# Altium schematic sheet 04_Sch.SchDoc (continued)

## Library data of the parts
AD4N AD4P TP8-TP11 TP13 = 101NJ-00001AU.01: SMD,材质磷青铜,塑高/针长无,耐压值50V,银白色,长x宽x高2.67x1.02x1.52mm; Allow Altenative Part: Y; Approved: YES; Categories: 连接器; COMPONENTLINK 1 DESCRIPTION: GetDatasheet; Database Table Name: Connector; Modify time: 2015年07月10号; Preferred: C; Remark: Verified by CRD（赖程奇） check list V1.0; serial number: 679; Category: 测试点; Component Kind: Standard; COMPONENTLINK 1 URL: http://www.keyelco.com/product-pdf.cfm?p=1353; Library Name: IA-04.DbLib; MOQ: 5000; Mounting Type: SurfaceMount; PACKAGE: Pipefittings; Part Number: 101NJ-00001AU.01; Pricing 1: 1.26; Supplier 1: KEYSTONE; Supplier Part Number 1: 5015; Value: 5015
C1 C3 C6 C8 C9 C21-C25 C30 C33 C35-C37 C39 C40 C43 C47 C50 C51 = 101CC-00142BW.01: SMD,电容量100nF,额定电压50V,偏差±10%,材质X7R,0603; Allow Altenative Part: Y; Approved: YES; Categories: 电容; COMPONENTLINK 1 DESCRIPTION: GetDatasheet; Database Table Name: CAP; Modify time: 2015年07月20; Rated Voltage: 50V; Remark: Verified by BU1（黄雄青） check list V1.0; serial number: 4; Temperature Coefficient: X7R; Tolerance: ±10%; Category: 贴片电容; Component Kind: Standard; COMPONENTLINK 1 URL: http://www.mouser.com/ds/2/447/UPY-GPHC_Y5V_6.3V-to-50V_5-14281.pdf; Library Name: IA-04.DbLib; MOQ: 1000; Mounting Type: SurfaceMount; PACKAGE: Tape&Reel; Part Number: 101CC-00142BW.01; Pricing 1: 0.029; Size: 603; Supplier 1: YAGEO; Supplier Part Number 1: CC0603KRX7R9BB104; Value: 100nF
C2 = 101CC-00418BW.01: SMD,电容量1.2nF,额定电压50V,偏差±10%,材质X7R,0603; Allow Altenative Part: Y; Approved: YES; Categories: 电容; COMPONENTLINK 1 DESCRIPTION: GetDatasheet; Database Table Name: CAP; Modify time: 2016年03月27号; Rated Voltage: 50V; Remark: Verified by BU1（黄雄青） check list V1.0; serial number: 113; Temperature Coefficient: X7R; Tolerance: ±10%; Category: 贴片电容; Component Kind: Standard; COMPONENTLINK 1 URL: http://www.yageo.com/documents/recent/UPY-GPHC_X7R_6.3V-to-50V_13.pdf; Library Name: IA-04.DbLib; MOQ: 4000; Mounting Type: SurfaceMount; PACKAGE: Tape&Reel; Part Number: 101CC-00418BW.01; Pricing 1: 0.02; Size: 0603; Supplier 1: YAGEO; Supplier Part Number 1: CC0603KRX7R9BB122; Value: 1.2nF
C4 = 101CC-00365BE.01: SMD,电容量10pF,额定电压50V,偏差±1%,材质NPO,0603; Allow Altenative Part: Y; Approved: YES; Categories: 电容; COMPONENTLINK 1 DESCRIPTION: GetDatasheet; Database Table Name: CAP; Modify time: 2015年07月10号; Rated Voltage: 50V; Remark: Verified by BU1（黄雄青） check list V1.0; serial number: 28; Temperature Coefficient: NPO; Tolerance: ±1%; Category: 贴片电容; Component Kind: Standard; COMPONENTLINK 1 URL: http://www.samsungsem.com/cn/product/passive-component/mlcc/general-n-high-cap/index.jsp; Library Name: IA-04.DbLib; MOQ: 4000; Mounting Type: SurfaceMount; PACKAGE: Tape&Reel; Part Number: 101CC-00365BE.01; Pricing 1: 0.14; Size: 0603; Supplier 1: Samsung; Supplier Part Number 1: CL10C100FB8NNNC; Value: 10pF
C5 = 101CC-00433BW.01: SMD,电容量100pF,额定电压50V,偏差±5%,材质NPO,0603; Allow Altenative Part: Y; Approved: YES; Categories: 电容; COMPONENTLINK 1 DESCRIPTION: GetDatasheet; Database Table Name: CAP; Modify time: 2016年03月27号; Preferred: C; Rated Voltage: 50V; Remark: Verified by BU1（黄雄青） check list V1.0; serial number: 146; Temperature Coefficient: NPO; Tolerance: ±5%; Category: 贴片电容; Component Kind: Standard; COMPONENTLINK 1 URL: http://www.yageo.com/documents/recent/UPY-GP_NP0_16V-to-50V_13.pdf; Library Name: IA-04.DbLib; MOQ: 4000; Mounting Type: SurfaceMount; PACKAGE: Tape&Reel; Part Number: 101CC-00433BW.01; Pricing 1: 0.02; Size: 603; Supplier 1: YAGEO; Supplier Part Number 1: CC0603JRNPO9BN101; Value: 100pF
C7 C34 C45 = 101CC-00250BW.01: SMD,电容量1uF,额定电压50V,偏差±10%,材质X7R,0603; Allow Altenative Part: Y; Approved: YES; Categories: 电容; COMPONENTLINK 1 DESCRIPTION: GetDatasheet; Database Table Name: CAP; Modify time: 2015年11月04号; Preferred: P; Rated Voltage: 50V; Remark: Verified by BU1（黄雄青） check list V1.0; serial number: 57; Temperature Coefficient: X7R; Tolerance: ±10%; Category: 贴片电容; Component Kind: Standard; COMPONENTLINK 1 URL: http://www.yageo.com/documents/recent/UPY-GPHC_X7R_6.3V-to-50V-v12.pdf; Library Name: IA-04.DbLib; MOQ: 4000; Mounting Type: SurfaceMount; PACKAGE: Tape&Reel; Part Number: 101CC-00250BW.01; Pricing 1: 0.752; Size: 0603; Supplier 1: YAGEO; Supplier Part Number 1: CC0603KRX7R9BB105; Value: 1uF
C10 C48 C49 = 101CE-00014GK.01: SMD,100uF,35V,±20%,6.3x5.8mm; Allow Altenative Part: Y; Approved: YES; Categories: 电容; COMPONENTLINK 1 DESCRIPTION: ShowDatasheet; Database Table Name: CAP_Alum; Modify time: 2016年08月22号; Remark: Verified by BU1（黄雄青） check list V1.0; serial number: 42; Applications: Automotive; Category: 电解电容; Component Kind: Standard; COMPONENTLINK 1 URL: http://industrial.panasonic.com/cdbs/www-data/pdf/RDE0000/ABA0000C35.pdf; Library Name: IA-04.DbLib; Max Thickness: 5.8; MOQ: 5000; Mounting Type: SurfaceMount; Operating Temperature: -55°C ~ 105°C; PACKAGE: Tape&Reel; Part Number: 101CE-00014GK.01; Pricing 1: 1.21; Rated Voltage: 35V; Size: 6.3; Supplier 1: Panasonic; Supplier Part Number 1: EEE-FT1V101AP; Tolerance: ±20%; Value: 100uF
C11-C15 C17-C20 = 101CC-00360OD.01: SMD,电容量10uF,额定电压50V,偏差±10%,材质X5R,1206; Allow Altenative Part: Y; Approved: YES; Categories: 电容; COMPONENTLINK 1 DESCRIPTION: GetDatasheet; Database Table Name: CAP; Modify time: 2017年01月24号; Rated Voltage: 50V; Remark: Verified by BU1（黄雄青） check list V1.0; serial number: 186; Temperature Coefficient: X5R; Tolerance: ±10%; Category: 贴片电容; Component Kind: Standard; COMPONENTLINK 1 URL: https://product.tdk.com/info/en/catalog/datasheets/mlcc_commercial_general_en.pdf; Library Name: IA-04.DbLib; MOQ: 2000; Mounting Type: SurfaceMount; PACKAGE: Tape&Reel; Part Number: 101CC-00360OD.01; Pricing 1: 2.2; Size: 1206; Supplier 1: TDK; Supplier Part Number 1: C3216X5R1H106K160AB; Value: 10uF
C16 = 101CE-00033GK.01: SMD,电容量100uF,额定电压50V,偏差±20%,8.0x10.2mm; Allow Altenative Part: Y; Categories: 电容; COMPONENTLINK 1 DESCRIPTION: ShowDatasheet; Database Table Name: CAP_Alum; Modify time: 2017年06月12号; Rated Voltage: 50V; serial number: 51; Tolerance: ±20%; Applications: Automotive; Category: 电解电容; Component Kind: Standard; COMPONENTLINK 1 URL: https://industrial.panasonic.com/cdbs/www-data/pdf/RDE0000/ABA0000C1145.pdf; Library Name: IA-04.DbLib; Max Thickness: 10.2; Mounting Type: SurfaceMount; Operating Temperature: -40°C ~ 85°C; PACKAGE: Tape&Reel; Part Number: 101CE-00033GK.01; Size: 8; Supplier 1: Panasonic; Supplier Part Number 1: EEE-1HA101UP; Value: 100uF
C26 C38 C41 C42 = 101CC-00442BW.01: SMD,电容量10uF,额定电压50V,偏差±10%,材质X7R,0805; Allow Altenative Part: Y; Approved: YES; Categories: 电容; COMPONENTLINK 1 DESCRIPTION: GetDatasheet; Database Table Name: CAP; Modify time: 2016年05月12号; Preferred: P; Rated Voltage: 50V; Remark: Verified by BU1（黄雄青） check list V1.0; serial number: 163; Temperature Coefficient: X7R; Tolerance: ±10%; Category: 贴片电容; Component Kind: Standard; COMPONENTLINK 1 URL: http://www.yageo.com/documents/recent/UPY-GPHC_X7R_6.3V-to-50V_13.pdf; Library Name: IA-04.DbLib; MOQ: 3000; Mounting Type: SurfaceMount; PACKAGE: Tape&Reel; Part Number: 101CC-00442BW.01; Pricing 1: 0.278; Size: 805; Supplier 1: YAGEO; Supplier Part Number 1: CC0805KKX7R9BB106; Value: 10uF
C27 C29 C32 = 101CC-00409BW.01: SMD,电容量22pF,额定电压50V,偏差±10%,材质NPO,0603; Allow Altenative Part: Y; Approved: YES; Categories: 电容; COMPONENTLINK 1 DESCRIPTION: GetDatasheet; Database Table Name: CAP; Modify time: 2017年01月24号; Preferred: C; Rated Voltage: 50V; Remark: Verified by BU1（黄雄青） check list V1.0; serial number: 236; Temperature Coefficient: NPO; Tolerance: ±10%; Category: 贴片电容; Component Kind: Standard; COMPONENTLINK 1 URL: http://www.yageo.com/NewPortal/yageodocoutput?fileName=/pdf/MLCC/UPY-GP_NP0_16V-to-50V_14.pdf; Library Name: IA-04.DbLib; MOQ: 4000; Mounting Type: SurfaceMount; PACKAGE: Tape&Reel; Part Number: 101CC-00409BW.01; Pricing 1: 0.05; Size: 603; Supplier 1: YAGEO; Supplier Part Number 1: CC0603KRNPO9BN220; Value: 22pF
C28 = 101CC-00425BW.01: SMD,电容量10nF,额定电压50V,偏差±10%,材质X7R,0603; Allow Altenative Part: Y; Approved: YES; Categories: 电容; COMPONENTLINK 1 DESCRIPTION: GetDatasheet; Database Table Name: CAP; Modify time: 2016年03月27号; Preferred: C; Rated Voltage: 50V; Remark: Verified by BU1（黄雄青） check list V1.0; serial number: 119; Temperature Coefficient: X7R; Tolerance: ±10%; Category: 贴片电容; Component Kind: Standard; COMPONENTLINK 1 URL: http://www.yageo.com/documents/recent/UPY-GPHC_X7R_6.3V-to-50V_13.pdf; Library Name: IA-04.DbLib; MOQ: 4000; Mounting Type: SurfaceMount; PACKAGE: Tape&Reel; Part Number: 101CC-00425BW.01; Pricing 1: 0.02; Size: 0603; Supplier 1: YAGEO; Supplier Part Number 1: CC0603KRX7R9BB103; Value: 10nF
C31 = 101CC-00507BW.01: SMD,电容量2.2nF,额定电压50V,偏差±5%,材质X7R,0603; Allow Altenative Part: Y; Categories: 电容; COMPONENTLINK 1 DESCRIPTION: GetDatasheet; Database Table Name: CAP; Modify time: 2017年03月10号; Rated Voltage: 50V; serial number: 252; Temperature Coefficient: X7R; Tolerance: ±5%; Category: 贴片电容; Component Kind: Standard; COMPONENTLINK 1 URL: http://pdf1.alldatasheet.com/datasheet-pdf/view/536436/YAGEO/CC0402KRX5R4BB/+_35WW8VUvG.PcGhAztzybgh+HPR+/datasheet.pdf; Library Name: IA-04.DbLib; Mounting Type: SurfaceMount; PACKAGE: Tape&Reel; Part Number: 101CC-00507BW.01; Size: 0603; Supplier 1: YAGEO; Supplier Part Number 1: CC0603JRX7R9BB222; Value: 2.2nF
C44 = 101CC-00150BW.01: SMD,电容量1nF,额定电压50V,偏差±10%,材质X7R,0603; Allow Altenative Part: Y; Approved: YES; Categories: 电容; COMPONENTLINK 1 DESCRIPTION: GetDatasheet; Database Table Name: CAP; Modify time: 2015年11月04号; Preferred: C; Rated Voltage: 50V; Remark: Verified by BU1（黄雄青） check list V1.0; serial number: 57; Temperature Coefficient: X7R; Tolerance: ±10%; Category: 贴片电容; Component Kind: Standard; COMPONENTLINK 1 URL: http://www.yageo.com/documents/recent/UPY-GPHC_X7R_6.3V-to-50V-v12.pdf; Library Name: IA-04.DbLib; MOQ: 4000; Mounting Type: SurfaceMount; PACKAGE: Tape&Reel; Part Number: 101CC-00150BW.01; Pricing 1: 0.0278; Size: 603; Supplier 1: YAGEO; Supplier Part Number 1: CC0603KRX7R9BB102; Value: 1nF
C46 = 101CC-00433BW.01: SMD,电容量100pF,额定电压50V,偏差±5%,材质NPO,0603; Allow Altenative Part: Y; Approved: YES; Categories: 电容; COMPONENTLINK 1 DESCRIPTION: GetDatasheet; Database Table Name: CAP; Modify time: 2016年03月27号; Preferred: C; Rated Voltage: 50V; Remark: Verified by BU1（黄雄青） check list V1.0; serial number: 123; Temperature Coefficient: NPO; Tolerance: ±5%; Category: 贴片电容; Component Kind: Standard; COMPONENTLINK 1 URL: http://www.yageo.com/documents/recent/UPY-GP_NP0_16V-to-50V_13.pdf; Library Name: IA-04.DbLib; MOQ: 4000; Mounting Type: SurfaceMount; PACKAGE: Tape&Reel; Part Number: 101CC-00433BW.01; Pricing 1: 0.02; Size: 0603; Supplier 1: YAGEO; Supplier Part Number 1: CC0603JRNPO9BN101; Value: 100pF
Cff1 = 101CC-00142BW.01: SMD,电容量100nF,额定电压50V,偏差±10%,材质X7R,0603; Allow Altenative Part: Y; Part Number: NC--101CC-00142BW.01; Approved: YES; Categories: 电容; COMPONENTLINK 1 DESCRIPTION: GetDatasheet; Database Table Name: CAP; Modify time: 2015年07月20; Rated Voltage: 50V; Remark: Verified by BU1（黄雄青） check list V1.0; serial number: 4; Temperature Coefficient: X7R; Tolerance: ±10%; Category: 贴片电容; Component Kind: Standard; COMPONENTLINK 1 URL: http://www.mouser.com/ds/2/447/UPY-GPHC_Y5V_6.3V-to-50V_5-14281.pdf; Library Name: IA-04.DbLib; MOQ: 1000; Mounting Type: SurfaceMount; PACKAGE: Tape&Reel; Pricing 1: 0.029; Size: 603; Supplier 1: YAGEO; Supplier Part Number 1: CC0603KRX7R9BB104; Value: 100nF
CH_N CH_P DA_O GND_MCU J5-J13 PP3V3_MCU PP5V = 1R5: SMD,直径1.5mm; Allow Altenative Part: Y; Categories: 连接器; Database Table Name: OTS; serial number: 411; Category: 测试点; Component Kind: Standard; Library Name: IA-04.DbLib; Mounting Type: SurfaceMount; PACKAGE: Pipefittings; Part Number: 1R5
D1 = (no symbol name): SMD,封装外壳SMC（DO-214AB）,额定电压40V,电流5A; Allow Altenative Part: Y; Approved: YES; Categories: 二极管; COMPONENTLINK 1 DESCRIPTION: GetDatasheet; Modify time: 2018年06月25号; Rated Current: 20A; Rated Voltage: 100V; Remark: Verified by BU2（孙泽） check list V1.0; serial number: 127; Category: 肖特基二极管; Component Kind: Standard; COMPONENTLINK 1 URL: https://www.st.com/resource/en/datasheet/stps20m100s.pdf; MOQ: 3000; Mounting Type: SurfaceMount; PACKAGE: Tape&Reel; Pricing 1: 8.4; Supplier 1: ST; Supplier Part Number 1: STPS20M100SG-TR; Value: STPS20M100SG-TR; Library Name: IAA1847400_Tiger-KB-FATP For Desk-N PS Board .SCHLIB
D2 = 101DS-00016BA.01: SMD,封装外壳SOD-323（0805）,额定电压20V,电流1A; Allow Altenative Part: Y; Approved: YES; Categories: 二极管; COMPONENTLINK 1 DESCRIPTION: GetDatasheet; Database Table Name: Diode; Modify time: 2015年07月28号; Rated Current: 1A; Rated Voltage: 20V; Remark: Verified by CRD（张宗良） check list V1.0; serial number: 35; Category: 肖特基二极管; Component Kind: Standard; COMPONENTLINK 1 URL: http://www.onsemi.com/pub_link/Collateral/NSR0320MW2T1-D.PDF; Library Name: IA-04.DbLib; MOQ: 1000; Mounting Type: SurfaceMount; PACKAGE: Tape&Reel; Part Number: 101DS-00016BA.01; Pricing 1: 0.61; Supplier 1: ON; Supplier Part Number 1: NSR0320MW2T1G; Value: NSR0320MW2T1G
D3 = 101DG-00024DC.01: SMD,SOD-323（0805）,额定电压75V,电流150mA; Allow Altenative Part: Y; Approved: YES; Categories: 二极管; COMPONENTLINK 1 DESCRIPTION: OpenDatasheet; Database Table Name: Diode; Modify time: 2017年01月07号; Rated Current: 150mA; Rated Voltage: 75V; Remark: Verified by CRD（张宗良） check list V1.0; serial number: 144; Category: 普通二极管; Component Kind: Standard; Library Name: IA-04.DbLib; Mounting Type: SurfaceMount; PACKAGE: Tape&Reel; Part Number: 101DG-00024DC.01; Supplier 1: 长电科技; Supplier Part Number 1: 1N4148WS; Value: 1N4148WS
D4 D5 = 101DT-00033AF.01: SMD,SMC（DO-214AB）,额定电压40V,电流5A; Allow Altenative Part: Y; Approved: YES; Categories: 二极管; COMPONENTLINK 1 DESCRIPTION: GetDatasheet; Database Table Name: Diode; Modify time: 2015年11月11号; Rated Current: 5A; Rated Voltage: 40V; Remark: Verified by CRD（张宗良） check list V1.0; serial number: 22; Category: 肖特基二极管; Component Kind: Standard; COMPONENTLINK 1 URL: http://www.diodes.com/_files/datasheets/ds13012.pdf; Library Name: IA-04.DbLib; MOQ: 3000; Mounting Type: SurfaceMount; PACKAGE: Tape&Reel; Part Number: 101DT-00033AF.01; Pricing 1: 1.31285; Supplier 1: DIODES; Supplier Part Number 1: B540C-13-F; Value: B540C-13-F
F1 = 101FP-00031BI.01: SMD,0805,额定电压6V,额定电流0.5A,快断; Allow Altenative Part: Y; Approved: YES; Categories: 保险丝; COMPONENTLINK 1 DESCRIPTION: GetDatasheet; Database Table Name: Fuse; Modify time: 2017年01月07号; Rated Current: .5A; Remark: Verified by BU1（黄雄青） check list V1.0; serial number: 38; Category: 自恢复保险丝; Component Kind: Standard; Library Name: IA-04.DbLib; Mounting Type: SurfaceMount; PACKAGE: Tape&Reel; Part Number: 101FP-00031BI.01; Supplier 1: SEA&LAND; Supplier Part Number 1: SMD0805-050-6V; Value: SMD0805-050-6V
J1 = 101NH-00001DJ.01: SMD,座子,封装脚距1.27mm,180度,2x20PIN,塑高/针长5.72mm/无,黑色; Allow Altenative Part: Y; Approved: YES; Categories: 连接器; COMPONENTLINK 1 DESCRIPTION: GetDatasheet; Database Table Name: Connector; Modify time: 2016年1月11号; Preferred: P; Remark: Verified by CRD（赖程奇） check list V1.0; serial number: 313; Category: 板对板连接器; Component Kind: Standard; COMPONENTLINK 1 URL: http://www.hkjb888.com/product.asp?page=9; Library Name: IA-04.DbLib; MOQ: 100; Mounting Type: SurfaceMount; PACKAGE: Pipefittings; Part Number: 101NH-00001DJ.01; Supplier 1: 骏佳; Supplier Part Number 1: BCM2571-4001B01B1BB; Value: BCM2571-4001B01B1BB
J2 = 101NH-00041BG.01: SMD,座子,封装脚距1.27mm,180度,2x12PIN,塑高/针长5.72mm/无,黑色; Allow Altenative Part: Y; Approved: YES; Categories: 连接器; COMPONENTLINK 1 DESCRIPTION: GetDatasheet; Database Table Name: Connector; Modify time: 2015年07月24号; Preferred: P; Remark: Verified by CRD（赖程奇） check list V1.0; serial number: 305; Category: 板对板连接器; Component Kind: Standard; COMPONENTLINK 1 URL: https://www.samtec.com/ftppub/pdf/tfm.pdf; Library Name: IA-04.DbLib; MOQ: 200; Mounting Type: SurfaceMount; PACKAGE: Pipefittings; Part Number: 101NH-00041BG.01; Supplier 1: 莱沃; Supplier Part Number 1: 2100113-12*2; Value: 2100113-12*2
J3 = 102NM-00004BV.01: DIP,封装脚距2.54mm,180度,1x6PIN,黑色; Allow Altenative Part: Y; Approved: YES; Categories: 连接器; COMPONENTLINK 1 DESCRIPTION: GetDatasheet; Database Table Name: Connector; Modify time: 2015年07月10号; Remark: Verified by CRD（赖程奇） check list V1.0; serial number: 103; Category: 单排母; Component Kind: Standard; COMPONENTLINK 1 URL: http://katalog.we-online.de/en/em/613_0xx_118_21/613_014_118_21?m=n&sp=http%3A%2F%2Fwww.we-online.com%2Fweb%2Fen%2Fwuerth_elektronik%2Fsearchpage.php%3Fsearch%3D61301411821; Library Name: IA-04.DbLib; MOQ: 100; Mounting Type: ThroughHole; PACKAGE: Bulk; Part Number: 102NM-00004BV.01; Pricing 1: 1.67; Supplier 1: wurth; Supplier Part Number 1: 61300611821; Value: 61300611821
J4 = 102NL-00006DJ.01: DIP,封装脚距2.54mm,180度,1x4PIN,上针/下针6.0/3.0mm,黑色; Allow Altenative Part: Y; Approved: YES; Categories: 连接器; COMPONENTLINK 1 DESCRIPTION: GetDatasheet; Database Table Name: Connector; Modify time: 2016年08月22号; Remark: Verified by CRD（赖程奇） check list V1.0; serial number: 216; Category: 单排针; Component Kind: Standard; COMPONENTLINK 1 URL: http://www.hkjb888.com/product.asp?action=detailed&id=1099&page=3&pid=245; Library Name: IA-04.DbLib; MOQ: 500; Mounting Type: ThroughHole; PACKAGE: Bulk; Part Number: 102NL-00006DJ.01; Pricing 1: 0.03; Supplier 1: 骏佳; Supplier Part Number 1: PAS1251-0401A01B1BA; Value: PAS1251-0401A01B1BA
J14 = 102NB-00022CY.01: DIP,座子,封装脚距2.54mm,180度,1x2PIN,塑高/针长7.0/3.40mm,白色; Allow Altenative Part: Y; Approved: YES; Categories: 连接器; COMPONENTLINK 1 DESCRIPTION: GetDatasheet; Database Table Name: Connector; Modify time: 2016年1月11号; Preferred: C; Remark: Verified by CRD（赖程奇） check list V1.0; serial number: 45; Category: XH连接器; Component Kind: Standard; COMPONENTLINK 1 URL: http://www.fyconn.com/uploadfile/jpg/324.jpg; Library Name: IA-04.DbLib; MOQ: 100; Mounting Type: ThroughHole; PACKAGE: Bulk; Part Number: 102NB-00022CY.01; Pricing 1: 0.251; Supplier 1: 富盈源; Supplier Part Number 1: XH-02A; Value: XH-02A
L1 = 101LP-00036BS.01: SMD,电感值33uH,精度±20%,额定电流10.7A,屏蔽式,17.15x17.15/7mm; Allow Altenative Part: Y; Approved: YES; Categories: 电感; COMPONENTLINK 1 DESCRIPTION: OpenDatasheet; Database Table Name: Inductor; Modify time: 2015年11月21号; Rated Current: 10.7A; Remark: Verified by BU1（黄雄青） check list V1.0; serial number: 24; Tolerance: ±20%; Category: 功率电感; Component Kind: Standard; COMPONENTLINK 1 URL: http://www.vishay.com/docs/34282/lp67gz11.pdf; Library Name: IA-04.DbLib; MOQ: 1000; Mounting Type: SurfaceMount; Operating Temperature: -55°C~155°C; PACKAGE: Tape&Reel; Part Number: 101LP-00036BS.01; Pricing 1: 19.635; Size: 17.15x17.15x7mm; Supplier 1: Vishay; Supplier Part Number 1: IHLP6767GZER330M11; Value: 33uH
L2 = 101LF-00015AV.01: SMD,电感值无,额定电流1.5A,屏蔽式,0805,阻值/频率600R/100MHz; Allow Altenative Part: Y; Approved: YES; Categories: 电感; COMPONENTLINK 1 DESCRIPTION: OpenDatasheet; Database Table Name: Inductor; Modify time: 2016年05月12号; Rated Current: 1.5A; Remark: Verified by BU1（黄雄青） check list V1.0; serial number: 51; Category: 磁珠; Component Kind: Standard; COMPONENTLINK 1 URL: http://search.murata.co.jp/Ceramy/image/img/PDF/ENG/L0110S0100BLM21P.pdf; Library Name: IA-04.DbLib; MOQ: 4000; Mounting Type: SurfaceMount; Operating Temperature: -55°C ~ 125°C; PACKAGE: Tape&Reel; Part Number: 101LF-00015AV.01; Pricing 1: 0.219; Size: 805; Supplier 1: Murata; Supplier Part Number 1: BLM21PG601SN1D; Value: 600R/100MHz
L3 L4 = 101LF-00024OD.01: SMD,电感值无,额定电流1A,屏蔽式,0603,阻值/频率470R/100MHz; Allow Altenative Part: Y; Approved: YES; Categories: 电感; COMPONENTLINK 1 DESCRIPTION: OpenDatasheet; Database Table Name: Inductor; Modify time: 2015年08月04号; Preferred: P; Rated Current: 1A; Remark: Verified by BU1（黄雄青） check list V1.0; serial number: 6; Tolerance: ±25%; Category: 磁珠; Component Kind: Standard; COMPONENTLINK 1 URL: http://product.tdk.com; Library Name: IA-04.DbLib; MOQ: 4000; Mounting Type: SurfaceMount; PACKAGE: Tape&Reel; Part Number: 101LF-00024OD.01; Pricing 1: 0.06; Size: 603; Supplier 1: TDK; Supplier Part Number 1: MPZ1608B471ATA00; Value: 470R/100MHz
L_MCU1 LED1 LED2 = 101DL-00049CH: SMD,0603,2V,普亮,黄色,; Allow Altenative Part: Y; Categories: 二极管; COMPONENTLINK 1 DESCRIPTION: GetDatasheet; Database Table Name: Diode; Modify time: 2015年11月11号; Remark: 旧料号23011562; serial number: 228; Category: 发光二极管; Component Kind: Standard; COMPONENTLINK1DESCRIPTION: Get Datasheet; COMPONENTLINK 1 URL: http://media.digikey.com/pdf/Data%20Sheets/Everlight%20PDFs/19-213UYC,S530-A3,TR8.pdf; COMPONENTLINK1URL: http://media.digikey.com/pdf/Data%20Sheets/Everlight%20PDFs/19-213UYC,S530-A3,TR8.pdf; Footprint Path: 06-Diode\Diode.PcbLib; Footprint Ref: LED-0603; Library Name: IA-04.DbLib; Library Path: 06-Diode\Diode.SchLib; Library Ref: 19-213UYC/S530-A3/TR8; MOQ: 9000; Mounting Type: SurfaceMount; PACKAGE: Tape&Reel; Part Number: 101DL-00049CH; Pricing 1: .385; Rated Voltage: 2V; Supplier 1: 台湾亿光; Supplier Part Number 1: 19-213UYC/S530-A3/TR8; Value: 19-213UYC/S530-A3/TR8
R1 = 101RC-01669BW.01: SMD,阻值10R,精度±1%,0603,额定功率1/10W; Allow Altenative Part: Y; Approved: YES; Categories: 电阻; COMPONENTLINK 1 DESCRIPTION: OpenDatasheet; Database Table Name: RES; Modify time: 2016年03月27号; Preferred: C; Rated Power: 1/10W; Remark: Verified by BU2（叶俊雄） check list V1.0; serial number: 494; Tolerance: ±1%; Category: 贴片电阻; Component Kind: Standard; COMPONENTLINK 1 URL: http://www.yageo.com/NewPortal/yageodocoutput?fileName=/pdf/R-Chip/PYu-RC_Group_51_RoHS_L_71.pdf; Library Name: IA-04.DbLib; MOQ: 5000; Mounting Type: SurfaceMount; PACKAGE: Tape&Reel; Part Number: 101RC-01669BW.01; Pricing 1: 0.01; Size: 0603; Supplier 1: Yageo; Supplier Part Number 1: RC0603FR-0710RL; Value: 10R
R2-R9 R18 R21 R22 R33-R35 R37 R42-R45 R52 = 101RC-02008BW.01: SMD,阻值0R,精度±1%,0402,额定功率1/16W; Allow Altenative Part: Y; Approved: YES; Categories: 电阻; COMPONENTLINK 1 DESCRIPTION: OpenDatasheet; Database Table Name: RES; Modify time: 2017年01月07号; Preferred: C; Rated Power: 1/16W; Remark: Verified by BU3（吴明徽）check list V1.0; serial number: 647; Tolerance: ±1%; Category: 贴片电阻; Component Kind: Standard; Library Name: IA-04.DbLib; Mounting Type: SurfaceMount; PACKAGE: Tape&Reel; Part Number: 101RC-02008BW.01; Size: 0402; Supplier 1: YAGEO; Supplier Part Number 1: RC0402FR-070RL; Value: 0R
R10 = 101RC-02279BW.01: 安装方式SMD,阻值49.9k,精度±0.1%,0603,额定功率1/10W; Allow Altenative Part: Y; Categories: 电阻; COMPONENTLINK 1 DESCRIPTION: OpenDatasheet; Database Table Name: RES; Modify time: 2018年5月12号; Rated Power: 1/10W; serial number: 860; Tolerance: ±0.1%; Category: 贴片电阻; Component Kind: Standard; COMPONENTLINK 1 URL: http://www.mouser.cn/datasheet/2/447/PYu-RC_Group_51_RoHS_L_9-1314892.pdf; Library Name: IA-04.DbLib; Mounting Type: SurfaceMount; PACKAGE: Tape&Reel; Part Number: 101RC-02279BW.01; Size: 0603; Supplier 1: YAGEO; Supplier Part Number 1: RT0603BRE0749K9L; Value: 49.9k
R11 = 101RC-01171BS.01: SMD,阻值50K,精度±0.1%,0603,额定功率3/20W; Allow Altenative Part: Y; Approved: YES; Categories: 电阻; COMPONENTLINK 1 DESCRIPTION: OpenDatasheet; Database Table Name: RES; Modify time: 2015年11月27号; Rated Power: 3/20W; Remark: Verified by BU3（吴明徽）check list V1.0; serial number: 163; Tolerance: ±0.1%; Category: 贴片电阻; Component Kind: Standard; COMPONENTLINK 1 URL: http://www.vishay.com/docs/60057/pnm.pdf; Library Name: IA-04.DbLib; MOQ: 5000; Mounting Type: SurfaceMount; PACKAGE: Tape&Reel; Part Number: 101RC-01171BS.01; Pricing 1: 9.21691; Size: 0603; Supplier 1: Vishay; Supplier Part Number 1: PNM0603E5002BST5; Value: 50K
R12 R13 R36 = 101RC-00400BW.01: SMD,阻值1K,精度±1%,0603,额定功率1/10W; Allow Altenative Part: Y; Approved: YES; Categories: 电阻; COMPONENTLINK 1 DESCRIPTION: OpenDatasheet; Database Table Name: RES; Modify time: 2015年11月27号; Preferred: P; Rated Power: 1/10W; Remark: Verified by BU2（叶俊雄）& BU4（陈雪莹） check list V1.0; serial number: 79; Tolerance: ±1%; Category: 贴片电阻; Component Kind: Standard; COMPONENTLINK 1 URL: http://www.yageo.com/exep/pages/download/literatures/PYu-R_Marking_2.pdf; Library Name: IA-04.DbLib; MOQ: 5000; Mounting Type: SurfaceMount; PACKAGE: Tape&Reel; Part Number: 101RC-00400BW.01; Pricing 1: 0.01287; Size: 0603; Supplier 1: YAGEO; Supplier Part Number 1: RC0603FR-071KL; Value: 1K
R14 = 101RC-01935BW.01: SMD,阻值200R,精度±0.1%,0603,额定功率1/10W; Allow Altenative Part: Y; Approved: YES; Categories: 电阻; COMPONENTLINK 1 DESCRIPTION: OpenDatasheet; Database Table Name: RES; Modify time: 2016年10月28号; Rated Power: 1/10W; Remark: Verified by BU3（吴明徽）check list V1.0; serial number: 563; Tolerance: ±0.1%; Category: 贴片电阻; Component Kind: Standard; COMPONENTLINK 1 URL: http://www.yageo.com/documents/recent/PYu-RT_1-to-0.05_RoHS_L_6.pdf; Library Name: IA-04.DbLib; MOQ: 5000; Mounting Type: SurfaceMount; PACKAGE: Tape&Reel; Part Number: 101RC-01935BW.01; Pricing 1: 0.42; Size: 0603; Supplier 1: YAGEO; Supplier Part Number 1: RT0603BRE07200RL; Value: 200R
R15 R26-R28 = 101RC-01741BW.01: SMD,阻值10K,精度±1%,0603,额定功率1/10W; Allow Altenative Part: Y; Approved: YES; Categories: 电阻; COMPONENTLINK 1 DESCRIPTION: OpenDatasheet; Database Table Name: RES; Modify time: 2016年03月27号; Preferred: P; Rated Power: 1/10W; Remark: Verified by BU2（叶俊雄）& BU4（陈雪莹） check list V1.0; serial number: 491; Tolerance: ±1%; Category: 贴片电阻; Component Kind: Standard; COMPONENTLINK 1 URL: http://www.yageo.com/NewPortal/yageodocoutput?fileName=/pdf/R-Chip/PYu-RC_Group_51_RoHS_L_8.pdf; Library Name: IA-04.DbLib; MOQ: 5000; Mounting Type: SurfaceMount; PACKAGE: Tape&Reel; Part Number: 101RC-01741BW.01; Pricing 1: 0.01; Size: 0603; Supplier 1: Yageo; Supplier Part Number 1: RC0603FR-0710KL; Value: 10K
R16 = 101RC-01741BW.01: SMD,阻值10K,精度±1%,0603,额定功率1/10W; Allow Altenative Part: Y; Approved: YES; Categories: 电阻; COMPONENTLINK 1 DESCRIPTION: OpenDatasheet; Database Table Name: RES; Modify time: 2016年03月27号; Preferred: P; Rated Power: 1/10W; Remark: Verified by BU2（叶俊雄）& BU4（陈雪莹） check list V1.0; serial number: 465; Tolerance: ±1%; Category: 贴片电阻; Component Kind: Standard; COMPONENTLINK 1 URL: http://www.yageo.com/NewPortal/yageodocoutput?fileName=/pdf/R-Chip/PYu-RC_Group_51_RoHS_L_8.pdf; Library Name: IA-04.DbLib; MOQ: 5000; Mounting Type: SurfaceMount; PACKAGE: Tape&Reel; Part Number: 101RC-01741BW.01; Pricing 1: 0.01; Size: 0603; Supplier 1: Yageo; Supplier Part Number 1: RC0603FR-0710KL; Value: 10K
R17 = 101RC-00062-0.01: SMD,阻值330K,精度±1%,0603,额定功率1/10W; Allow Altenative Part: Y; Approved: YES; Categories: 电阻; COMPONENTLINK 1 DESCRIPTION: OpenDatasheet; Database Table Name: RES; Modify time: 2015年07月10号; Rated Power: 1/10W; Remark: Verified by BU3（吴明徽）check list V1.0; serial number: 125; Tolerance: ±1%; Category: 贴片电阻; Component Kind: Standard; Library Name: IA-04.DbLib; MOQ: 5000; Mounting Type: SurfaceMount; PACKAGE: Tape&Reel; Part Number: 101RC-00062-0.01; Size: 0603; Value: 330K
R19 = 101RC-00096-0.01: SMD,阻值57.6K,精度±1%,0603,额定功率1/10W; Allow Altenative Part: Y; Approved: YES; Categories: 电阻; COMPONENTLINK 1 DESCRIPTION: OpenDatasheet; Database Table Name: RES; Modify time: 2015年07月10号; Rated Power: 1/10W; Remark: Verified by BU3（吴明徽）check list V1.0; serial number: 166; Tolerance: ±1%; Category: 贴片电阻; Component Kind: Standard; Library Name: IA-04.DbLib; MOQ: 5000; Mounting Type: SurfaceMount; PACKAGE: Tape&Reel; Part Number: 101RC-00096-0.01; Size: 0603; Value: 57.6K
R20 = 101RC-01938BW.01: SMD,阻值2K,精度±0.1%,0603,额定功率1/10W; Allow Altenative Part: Y; Approved: YES; Categories: 电阻; COMPONENTLINK 1 DESCRIPTION: OpenDatasheet; Database Table Name: RES; Modify time: 2016年10月28号; Rated Power: 1/10W; Remark: Verified by BU3（吴明徽）check list V1.0; serial number: 567; Tolerance: ±0.1%; Category: 贴片电阻; Component Kind: Standard; COMPONENTLINK 1 URL: http://www.yageo.com/documents/recent/PYu-RT_1-to-0.05_RoHS_L_6.pdf; Library Name: IA-04.DbLib; MOQ: 5000; Mounting Type: SurfaceMount; PACKAGE: Tape&Reel; Part Number: 101RC-01938BW.01; Pricing 1: 0.42; Size: 0603; Supplier 1: YAGEO; Supplier Part Number 1: RT0603BRE072KL; Value: 2K
R23 = 101RC-01697BW.01: SMD,阻值10K,精度±0.1%,0603,额定功率1/10W; Allow Altenative Part: Y; Approved: YES; Categories: 电阻; COMPONENTLINK 1 DESCRIPTION: OpenDatasheet; Database Table Name: RES; Modify time: 2016年2月17号; Preferred: C; Rated Power: 1/10W; Remark: Verified by BU2（叶俊雄）& BU3（杨培）& CRD（赖程奇）check list V1.0; serial number: 438; Tolerance: ±0.1%; Category: 贴片电阻; Component Kind: Standard; COMPONENTLINK 1 URL: http://www.yageo.com/documents/recent/PYu-RT_1-to-0.05_RoHS_L_6.pdf; Library Name: IA-04.DbLib; MOQ: 5000; Mounting Type: SurfaceMount; PACKAGE: Tape&Reel; Part Number: 101RC-01697BW.01; Pricing 1: 0.43559; Size: 0603; Supplier 1: YAGEO; Supplier Part Number 1: RT0603BRD0710KL; Value: 10K
R24 R29 R31 R38 = 101RC-01748BW.01: SMD,阻值0R,精度±1%,0603,额定功率1/10W; Allow Altenative Part: Y; Approved: YES; Categories: 电阻; COMPONENTLINK 1 DESCRIPTION: OpenDatasheet; Database Table Name: RES; Modify time: 2016年03月27号; Preferred: P; Rated Power: 1/10W; Remark: Verified by BU2（叶俊雄）& BU4（陈雪莹）check list V1.0; serial number: 496; Tolerance: ±1%; Category: 贴片电阻; Component Kind: Standard; COMPONENTLINK 1 URL: http://www.yageo.com/NewPortal/yageodocoutput?fileName=/pdf/R-Chip/PYu-RC_Group_51_RoHS_L_74.pdf; Library Name: IA-04.DbLib; MOQ: 5000; Mounting Type: SurfaceMount; PACKAGE: Tape&Reel; Part Number: 101RC-01748BW.01; Pricing 1: 0.01; Size: 0603; Supplier 1: Yageo; Supplier Part Number 1: RC0603FR-070RL; Value: 0R
R30 = 101RC-00557-0.01: SMD,阻值34.8K,精度±1%,0603,额定功率1/10W; Allow Altenative Part: Y; Approved: YES; Categories: 电阻; COMPONENTLINK 1 DESCRIPTION: OpenDatasheet; Database Table Name: RES; Modify time: 2015年07月10号; Rated Power: 1/10W; Remark: Verified by BU3（吴明徽）check list V1.0; serial number: 129; Tolerance: ±1%; Category: 贴片电阻; Component Kind: Standard; Library Name: IA-04.DbLib; MOQ: 5000; Mounting Type: SurfaceMount; PACKAGE: Tape&Reel; Part Number: 101RC-00557-0.01; Size: 0603; Value: 34.8K
R39 = 101RC-01224BW.01: SMD,阻值100R,精度±0.1%,0603,额定功率1/10W; Allow Altenative Part: Y; Approved: YES; Categories: 电阻; COMPONENTLINK 1 DESCRIPTION: OpenDatasheet; Database Table Name: RES; Modify time: 2016年1月11号; Rated Power: 1/10W; Remark: Verified by BU2（叶俊雄） check list V1.0; serial number: 419; Tolerance: ±0.1%; Category: 贴片电阻; Component Kind: Standard; COMPONENTLINK 1 URL: http://www.yageo.com/documents/recent/PYu-RT_1-to-0.05_RoHS_L_6.pdf; Library Name: IA-04.DbLib; MOQ: 5000; Mounting Type: SurfaceMount; PACKAGE: Tape&Reel; Part Number: 101RC-01224BW.01; Pricing 1: 0.315; Size: 0603; Supplier 1: YAGEO; Supplier Part Number 1: RT0603BRD07100RL; Value: 100R
R40 = 101RC-01581BW.01: SMD,阻值1K,精度±0.1%,0603,额定功率1/10W; Allow Altenative Part: Y; Approved: YES; Categories: 电阻; COMPONENTLINK 1 DESCRIPTION: OpenDatasheet; Database Table Name: RES; Modify time: 2016年05月12号; Preferred: C; Rated Power: 1/10W; Remark: Verified by BU2（叶俊雄）& CRD（赖程奇） check list V1.0; serial number: 531; Tolerance: ±0.1%; Category: 贴片电阻; Component Kind: Standard; COMPONENTLINK 1 URL: http://www.yageo.com/documents/recent/PYu-RT_1-to-0.05_RoHS_L_6.pdf; Library Name: IA-04.DbLib; MOQ: 5000; Mounting Type: SurfaceMount; PACKAGE: Tape&Reel; Part Number: 101RC-01581BW.01; Pricing 1: 0.42; Size: 0603; Supplier 1: YAGEO; Supplier Part Number 1: RT0603BRE-071KL; Value: 1K
R41 = 101RC-02008BW.01: SMD,阻值0R,精度±1%,0402,额定功率1/16W; Allow Altenative Part: Y; Part Number: NC______________101RC-02008BW.01; Approved: YES; Categories: 电阻; COMPONENTLINK 1 DESCRIPTION: OpenDatasheet; Database Table Name: RES; Modify time: 2017年01月07号; Preferred: C; Rated Power: 1/16W; Remark: Verified by BU3（吴明徽）check list V1.0; serial number: 647; Tolerance: ±1%; Category: 贴片电阻; Component Kind: Standard; Library Name: IA-04.DbLib; Mounting Type: SurfaceMount; PACKAGE: Tape&Reel; Size: 0402; Supplier 1: YAGEO; Supplier Part Number 1: RC0402FR-070RL; Value: 0R
R46 R49 = 101RC-01222BW.01: SMD,阻值30K,精度±0.1%,0603,额定功率1/10W; Allow Altenative Part: Y; Approved: YES; Categories: 电阻; COMPONENTLINK 1 DESCRIPTION: OpenDatasheet; Database Table Name: RES; Modify time: 2016年1月11号; Rated Power: 1/10W; Remark: Verified by BU3（吴明徽）check list V1.0; serial number: 430; Tolerance: ±0.1%; Category: 贴片电阻; Component Kind: Standard; COMPONENTLINK 1 URL: http://www.yageo.com/documents/recent/PYu-RT_1-to-0.05_RoHS_L_6.pdf; Library Name: IA-04.DbLib; MOQ: 5000; Mounting Type: SurfaceMount; PACKAGE: Tape&Reel; Part Number: 101RC-01222BW.01; Pricing 1: 0.3159; Size: 0603; Supplier 1: YAGEO; Supplier Part Number 1: RT0603BRD0730KL; Value: 30K
R47 R50 = 101RC-01058BW.01: SMD,阻值4.99K,精度±0.1%,0603,额定功率1/10W; Allow Altenative Part: Y; Approved: YES; Categories: 电阻; COMPONENTLINK 1 DESCRIPTION: OpenDatasheet; Database Table Name: RES; Modify time: 2015年08月05号; Rated Power: 1/10W; Remark: Verified by BU3（吴明徽）check list V1.0; serial number: 136; Tolerance: ±0.1%; Category: 贴片电阻; Component Kind: Standard; COMPONENTLINK 1 URL: http://www.yageo.com/documents/recent/PYu-RT_1-to-0.05_RoHS_L_6.pdf; Library Name: IA-04.DbLib; MOQ: 5000; Mounting Type: SurfaceMount; PACKAGE: Tape&Reel; Part Number: 101RC-01058BW.01; Pricing 1: 1.67; Size: 0603; Supplier 1: YAGEO; Supplier Part Number 1: RT0603BRB074K99L; Value: 4.99K
R48 R51 = 101RC-01084BW.01: SMD,阻值2.49K,精度±0.1%,0603,额定功率1/10W; Allow Altenative Part: Y; Approved: YES; Categories: 电阻; COMPONENTLINK 1 DESCRIPTION: OpenDatasheet; Database Table Name: RES; Modify time: 2015年08月05号; Rated Power: 1/10W; Remark: Verified by BU3（吴明徽）check list V1.0; serial number: 85; Tolerance: ±0.1%; Category: 贴片电阻; Component Kind: Standard; COMPONENTLINK 1 URL: http://www.mouser.com/ds/2/447/PYu-AT_51_RoHS_L_V02-559295.pdf; Library Name: IA-04.DbLib; MOQ: 5000; Mounting Type: SurfaceMount; PACKAGE: Tape&Reel; Part Number: 101RC-01084BW.01; Pricing 1: 0.29; Size: 0603; Supplier 1: YAGEO; Supplier Part Number 1: AT0603BRD072K49L; Value: 2.49K
RESET1 S1 = 101SA-00031BV.01: SMD,封装尺寸6.2x6.2x4.3mm,4PIN,装配角度180度,黑色; Allow Altenative Part: Y; Approved: YES; Categories: 开关; COMPONENTLINK 1 DESCRIPTION: OpenDatasheet; Database Table Name: KEY; Modify time: 2015年07月10号; Rated Current: 50mA; Rated Voltage: 12VDC; Remark: verified by BU9（吴明徽） check list V1.0; serial number: 7; Category: 轻触开关; Component Kind: Standard; COMPONENTLINK 1 URL: http://katalog.we-online.de/em/datasheet/4301x2.pdf; Library Name: IA-04.DbLib; MOQ: 400; Mounting Type: SurfaceMount; PACKAGE: Tape&Reel; Part Number: 101SA-00031BV.01; Pricing 1: 4.24; Size: 6.2x6.2x4.3mm; Supplier 1: wurth; Supplier Part Number 1: 430182043816; Value: 430182043816
RY1 = 101RF-00022BS.01: SMD,阻值0.005R,精度±1%,3637,额定功率3W; Allow Altenative Part: Y; Categories: 电阻; COMPONENTLINK 1 DESCRIPTION: OpenDatasheet; Database Table Name: RES_ESP; Modify time: 2017年06月26号; Rated Power: 3W; serial number: 100; Tolerance: ±1%; Category: 四线电阻; Component Kind: Standard; COMPONENTLINK 1 URL: http://www.vishaypg.com/docs/63089/csm.pdf; Library Name: IA-04.DbLib; Mounting Type: SurfaceMount; PACKAGE: Tape&Reel; Part Number: 101RF-00022BS.01; Size: 3637; Supplier 1: Vishay; Supplier Part Number 1: Y14880R00500F9W; Value: 0.005R
U1 = 101IG-00055AA.01: SMD,TSSOP-24,24PIN,集成PGA和基准电压源的4通道差分输入或7通道伪差分输入24位ADC(工作电压 2.7~3.6V或±1.8V); Allow Altenative Part: Y; Approved: YES; Categories: IC; COMPONENTLINK 1 DESCRIPTION: OpenDatasheet; Modify time: 2017年03月10号; Preferred: P; Remark: Verified by BU2（叶俊雄） check list V2.0; serial number: 552; Category: 数据转换IC; Component Kind: Standard; COMPONENTLINK 1 URL: http://www.analog.com/media/en/technical-documentation/data-sheets/AD7124-4.pdf; Database Table Name: IC; Library Name: IA-04.DbLib; Mounting Type: SurfaceMount; PACKAGE: Tape&Reel; Part Number: 101IG-00055AA.01; Supplier 1: ADI; Supplier Part Number 1: AD7124-4BRUZ; Value: AD7124-4BRUZ
U2 = INA28x: Component Kind: Standard; Library Name: My_Lib.SCHLIB
U3 = 101IG-00052AA.01: SMD,LFCSP-UD-8,8PIN,功能描述单通道16位数模转换器(工作电压2.7~5.5V); Allow Altenative Part: Y; Approved: YES; Categories: IC; COMPONENTLINK 1 DESCRIPTION: OpenDatasheet; Modify time: 2016年11月20号; Preferred: P; Remark: Verified by CRD（李宗良） check list V1.0; serial number: 429; Category: 数据转换IC; Component Kind: Standard; COMPONENTLINK1DESCRIPTION: Open Datasheet; COMPONENTLINK 1 URL: http://www.analog.com/media/en/technical-documentation/data-sheets/AD5683R_5682R_5681R_5683.pdf; COMPONENTLINK1URL: http://www.analog.com/media/en/technical-documentation/data-sheets/AD5683R_5682R_5681R_5683.pdf; Database Table Name: IC; Footprint Path: 08-IC\IC.PcbLib; Footprint Ref: LFCSP8-0R5-2X2-T; Library Name: IA-04.DbLib; Library Path: 08-IC\IC.SchLib; Library Ref: AD5683RACPZ-RL7; MOQ: 3000; Mounting Type: SurfaceMount; PACKAGE: Tape&Reel; Part Number: 101IG-00052AA.01; Pricing 1: 22.8; Supplier 1: ADI; Supplier Part Number 1: AD5683RACPZ-RL7; Value: AD5683RACPZ-RL7
U4 = 101IB-00003BK.01: SMD,LQFP-100,100PIN,32位（工作电压2.0V~3.6 V）; Allow Altenative Part: Y; Approved: YES; Categories: IC; COMPONENTLINK 1 DESCRIPTION: OpenDatasheet; Modify time: 2015年07月10号; Remark: Verified by BU2（叶俊雄） check list V1.0; serial number: 116; Category: 微处理器IC; Component Kind: Standard; COMPONENTLINK1DESCRIPTION: http://www.st.com/web/en/resource/technical/document/datasheet/CD00191185.pdf; COMPONENTLINK 1 URL: http://www.st.com/web/en/resource/technical/document/datasheet/CD00191185.pdf; COMPONENTLINK2DESCRIPTION: Open Datasheet; Database Table Name: IC; Footprint Path: 08-IC\IC.PcbLib; Footprint Ref: LQFP100-0R50-14X14; Library Name: IA-04.DbLib; Library Path: 08-IC\IC.SchLib; Library Ref: STM32F103VCT6TR; MOQ: 1000; Mounting Type: SurfaceMount; PACKAGE: Tape&Reel; Part Number: 101IB-00003BK.01; Pricing 1: 37.24; Supplier 1: ST; Supplier Part Number 1: STM32F103VCT6TR; Value: STM32F103VCT6TR
U5 = U1: TPS54560DDAR; Manufacturer Name: Texas Instruments; Component Kind: Standard; Library Name: 2018-05-10_05-49-361.SCHLIB; Manufacturer Part Number: TPS54560DDAR; PackageReference: TPS54560DDAR; Value: Value
U6 = 101ID-00002AS.01: SMD,DFN-8,8PIN,功能描述I^2C/SMBus通讯数字温度传感器（工作电压 3~ 3.6 V）; Allow Altenative Part: Y; Approved: YES; COMPONENTLINK 1 DESCRIPTION: OpenDatasheet; Modify time: 2017年01月07号; Remark: Verified by CRD（李宗良） check list V1.0; serial number: 465; Category: 传感器IC; Component Kind: Standard; COMPONENTLINK 1 URL: http://pdf1.alldatasheet.com/datasheet-pdf/view/195329/MICROCHIP/MCP9805T-BE/MC/+0JW44AVKhwSP.KLufTKZppCHFxRu6eU+/datasheet.pdf; Database Table Name: IC; Library Name: IA-04.DbLib; Mounting Type: SurfaceMount; PACKAGE: Tape&Reel; Part Number: 101ID-00002AS.01; Supplier 1: Microchip; Supplier Part Number 1: MCP9805T-BE/MC; Value: MCP9805T-BE/MC
U7 = 101IA-00133BN.01: SMD,SOT-223-3,3PIN,线性稳压器(输入电压 4.7V~15V,输出电压3.3V,输出电流800mA); Allow Altenative Part: Y; Approved: YES; Categories: IC; Modify time: 2017年01月07号; Preferred: P; Remark: Verified by CRD（李宗良） check list V1.0; serial number: 498; Category: 电源管理IC; Component Kind: Standard; COMPONENTLINK 1 URL: https://www.ti.com/lit/ds/symlink/tlv1117-50.pdf; Database Table Name: IC; Library Name: IA-04.DbLib; Mounting Type: SurfaceMount; PACKAGE: Tape&Reel; Part Number: 101IA-00133BN.01; Supplier 1: TI; Supplier Part Number 1: TLV1117-33IDCYR; Value: TLV1117-33IDCYR
X1 = 101XB-00038BP.01: SMD,材质金属外壳,封装外壳HC-49SMD,精度±30ppm,震荡频率8MHz; Allow Altenative Part: Y; Approved: YES; Categories: 晶振; COMPONENTLINK 1 DESCRIPTION: OpenDatasheet; Database Table Name: XTAL; Modify time: 2017年01月07号; Remark: Verified by BU1（吴明徽）check list V1.0; serial number: 39; Category: 无源晶振; Component Kind: Standard; COMPONENTLINK 1 URL: http://www.farnell.com/datasheets/1497895.pdf; Library Name: IA-04.DbLib; Mounting Type: SurfaceMount; PACKAGE: Tape&Reel; Part Number: 101XB-00038BP.01; Size: HC-49S; Supplier 1: TXC; Supplier Part Number 1: 8MHz; Value: 8MHz
